# Altium schematic sheet ALLPRINT-CAPBOARD-677512-GENERAL.SchDoc
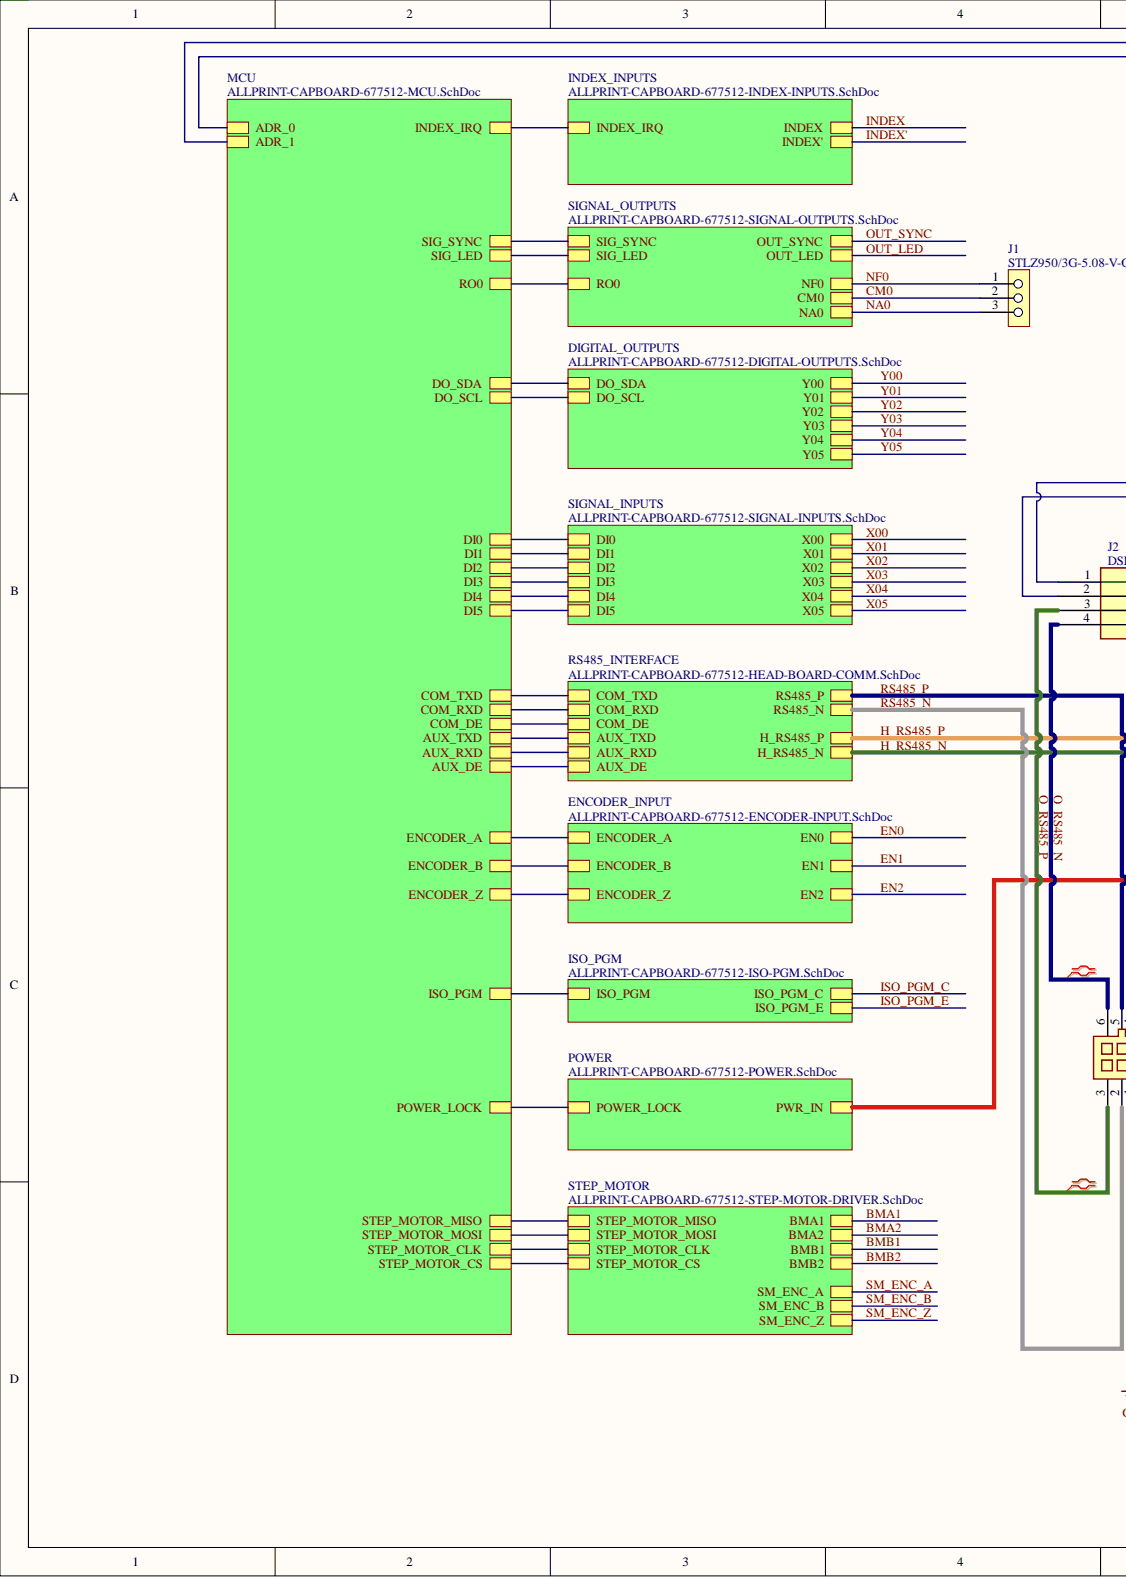
[diagram p0: ALLPRINT-CAPBOARD-677512-GENERAL.SchDoc, left]
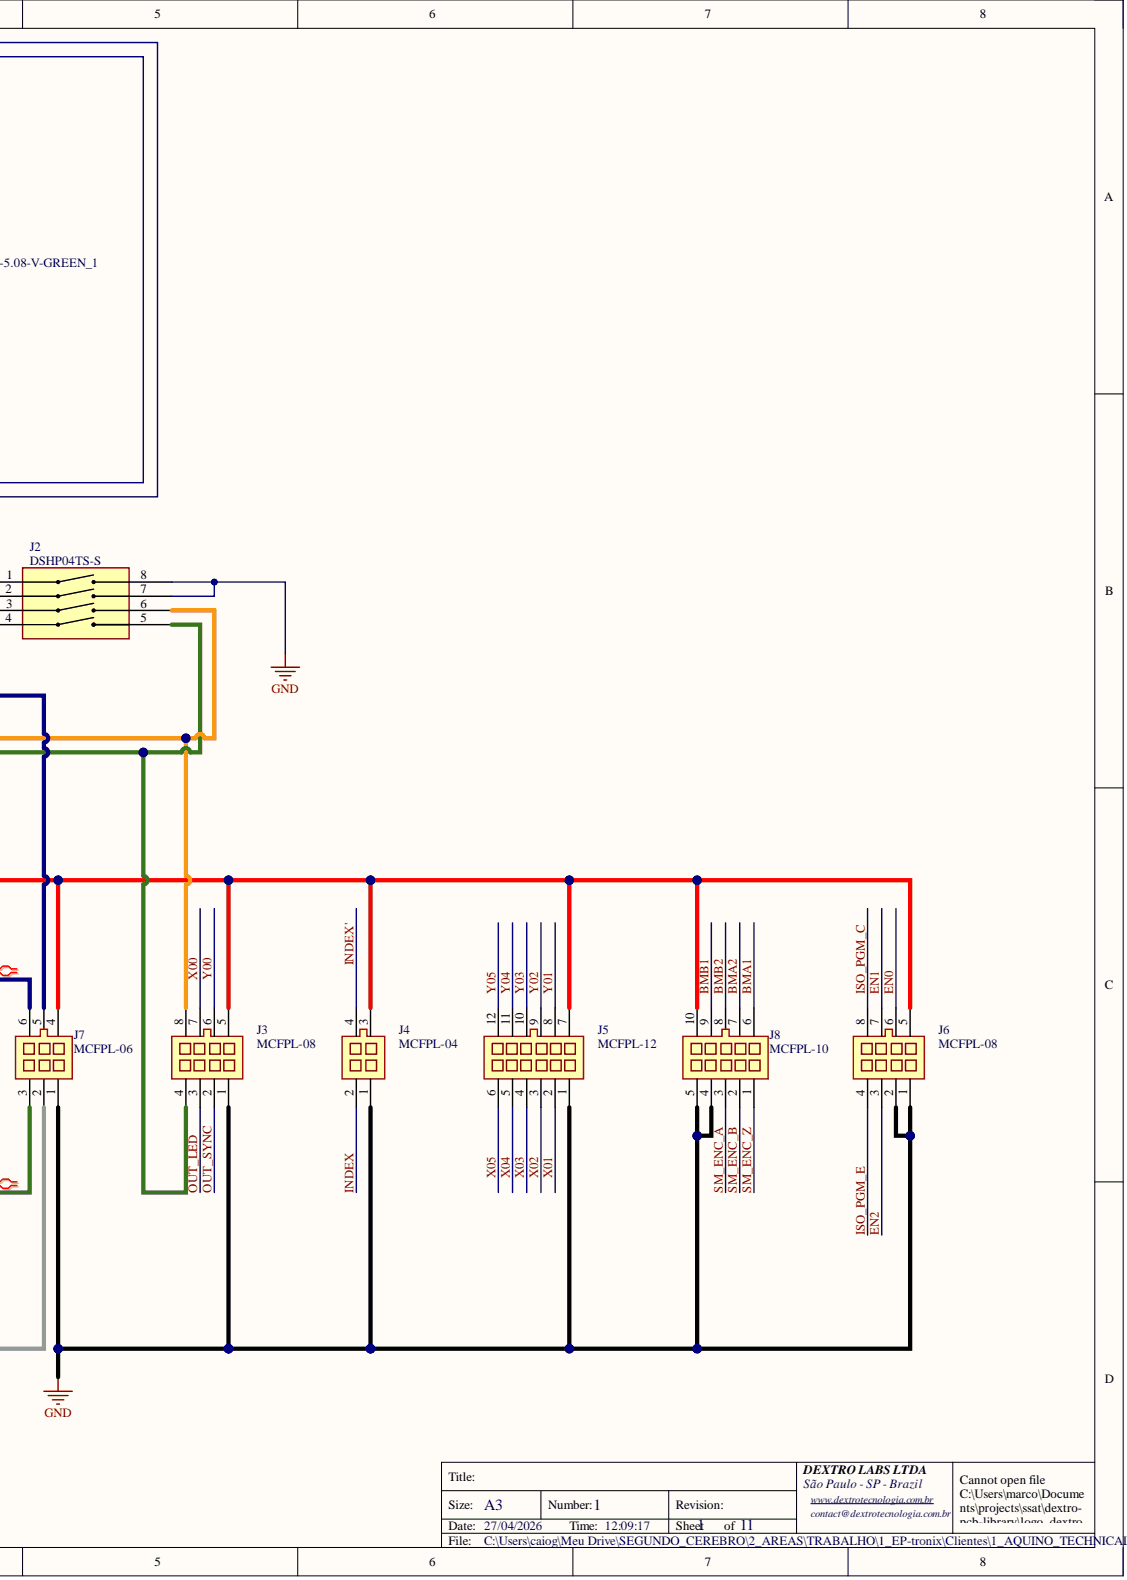
[diagram p1: ALLPRINT-CAPBOARD-677512-GENERAL.SchDoc, right]

## Parts
J1  STLZ950/3G-5.08-V-GREEN_1  [STLZ950/3G-5.08-V]
J2  DSHP04TS-S  [SW-SMD_8P-L6.7-W5.4-P1.27-LS8.5]
J3  MCFPL-08  [MCFPL-08]
J4  MCFPL-04  [MCFPL-04]
J5  MCFPL-12  [MCFPL-12]
J6  MCFPL-08  [MCFPL-08]
J7  MCFPL-06  [MCFPL-06]
J8  MCFPL-10  [MCFPL-10]

## Nets
GND: J2.7 J2.8 J3.1 J4.1 J5.1 J6.1 J6.2 J7.1 J8.4 J8.5
BMA1: J8.6
BMA2: J8.7
BMB1: J8.9
BMB2: J8.8
CM0: J1.2
EN0: J6.6
EN1: J6.7
EN2: J6.3
H_RS485_N: J2.5 J3.4
H_RS485_P: J2.6 J3.8
INDEX: J4.2
INDEX': J4.4
ISO_PGM_C: J6.8
ISO_PGM_E: J6.4
NA0: J1.3
NF0: J1.1
O_RS485_N: J2.4 J7.6
O_RS485_P: J2.3 J7.3
OUT_LED: J3.3
OUT_SYNC: J3.2
RS485_N: J7.2
RS485_P: J7.5
SM_ENC_A: J8.3
SM_ENC_B: J8.2
SM_ENC_Z: J8.1
X00: J3.7
X01: J5.2
X02: J5.3
X03: J5.4
X04: J5.5
X05: J5.6
Y00: J3.6
Y01: J5.8
Y02: J5.9
Y03: J5.10
Y04: J5.11
Y05: J5.12
NetJ2_1: J2.1
NetJ2_2: J2.2
NetJ3_5: J3.5 J4.3 J5.7 J6.5 J7.4 J8.10
AUX_DE:
AUX_RXD:
AUX_TXD:
COM_DE:
COM_RXD:
COM_TXD:
DI0:
DI1:
DI2:
DI3:
DI4:
DI5:
DO_SCL:
DO_SDA:
ENCODER_A:
ENCODER_B:
ENCODER_Z:
INDEX_IRQ:
ISO_PGM:
POWER_LOCK:
RO0:
SIG_LED:
SIG_SYNC:
STEP_MOTOR_CLK:
STEP_MOTOR_CS:
STEP_MOTOR_MISO:
STEP_MOTOR_MOSI:

## Library data of the parts
J1 = STLZ950/3G-5.08-V-GREEN: CONECTOR PHOENIX MECANO 3 VIAS PASSO 5,08mm VERDE 180º; Component Kind: Standard; Library Name: dextro-labs.SchLib
J2 = DSHP04TS-S: 4 24V 25mA SPST 3,000 Cycles Black Slide, Recessed SMD-8P,6.7x5.4mm DIP Switches/SIP Switches ROHS; Component Kind: Standard; LCSC: C319050; Library Name: dextro-labs.SchLib
J3 J6 = MCFPL-08: CONECTOR-METALTEX-PASSO-3mm-90 GRAUS MONTAGEM PLACA-COMPATIVEL MICROFIT-8VIAS  5A-250VCA; Component Kind: Standard; Library Name: dextro-labs.SchLib
J4 = MCFPL-04: CONECTOR-METALTEX-PASSO-3mm-90 GRAUS MONTAGEM PLACA-COMPATIVEL MICROFIT-4VIAS  5A-250VCA; Component Kind: Standard; Library Name: dextro-labs.SchLib
J5 = MCFPL-12: CONECTOR-METALTEX-PASSO-3mm-90 GRAUS MONTAGEM PLACA-COMPATIVEL MICROFIT-12VIAS  5A-250VCA; Component Kind: Standard; Library Name: dextro-labs.SchLib
J7 = MCFPL-06: CONECTOR-METALTEX-PASSO-3mm-90 GRAUS MONTAGEM PLACA-COMPATIVEL MICROFIT-6VIAS  5A-250VCA; Component Kind: Standard; Library Name: dextro-labs.SchLib
J8 = MCFPL-10: CONECTOR-METALTEX-PASSO-3mm-90 GRAUS MONTAGEM PLACA-COMPATIVEL MICROFIT-10VIAS  5A-250VCA; Component Kind: Standard; Library Name: dextro-labs.SchLib
